# Altium schematic sheet （6）Memory.Sch
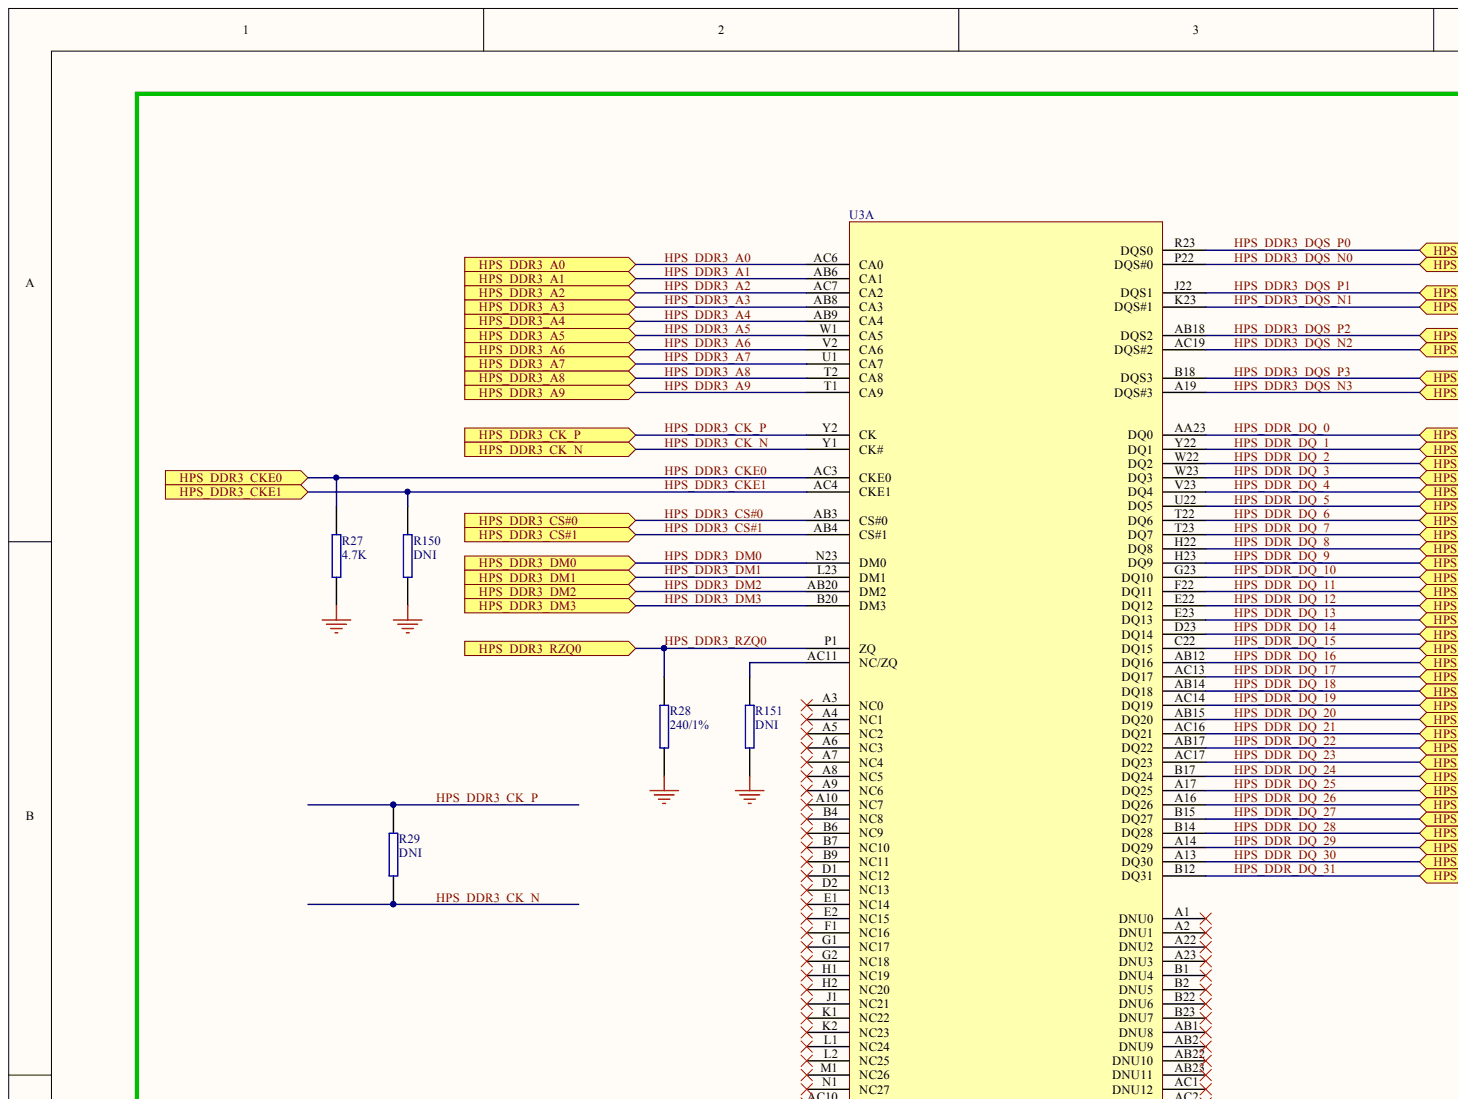
[diagram p0: （6）Memory.Sch, top left]
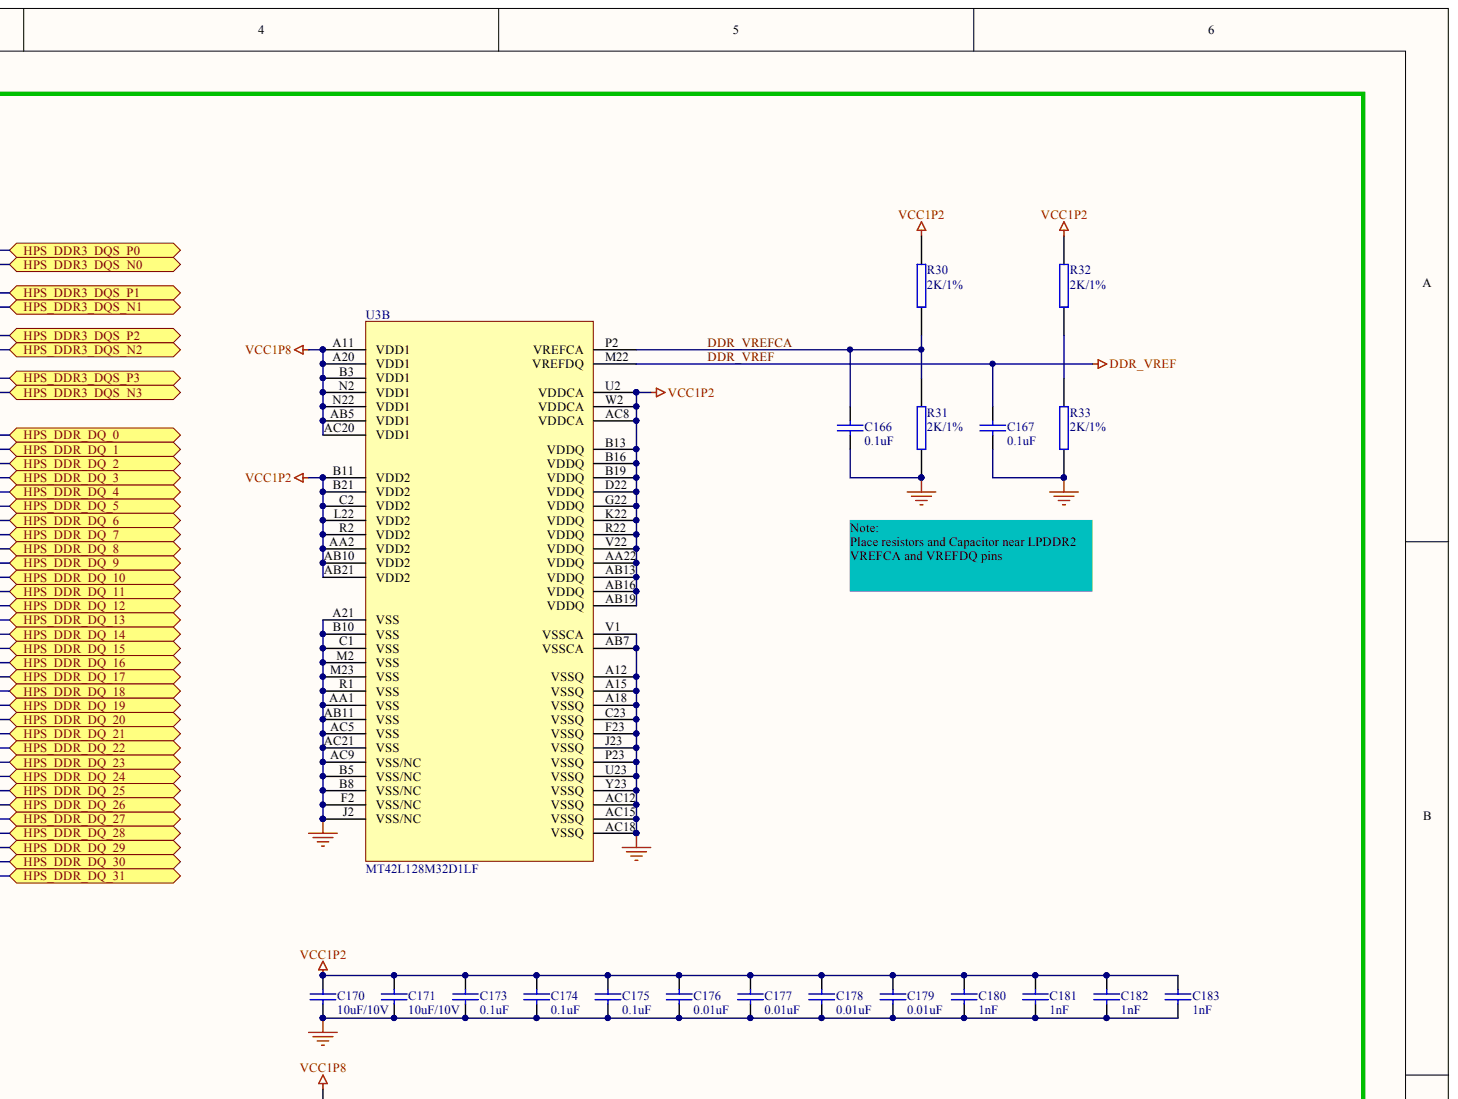
[diagram p1: （6）Memory.Sch, top right]
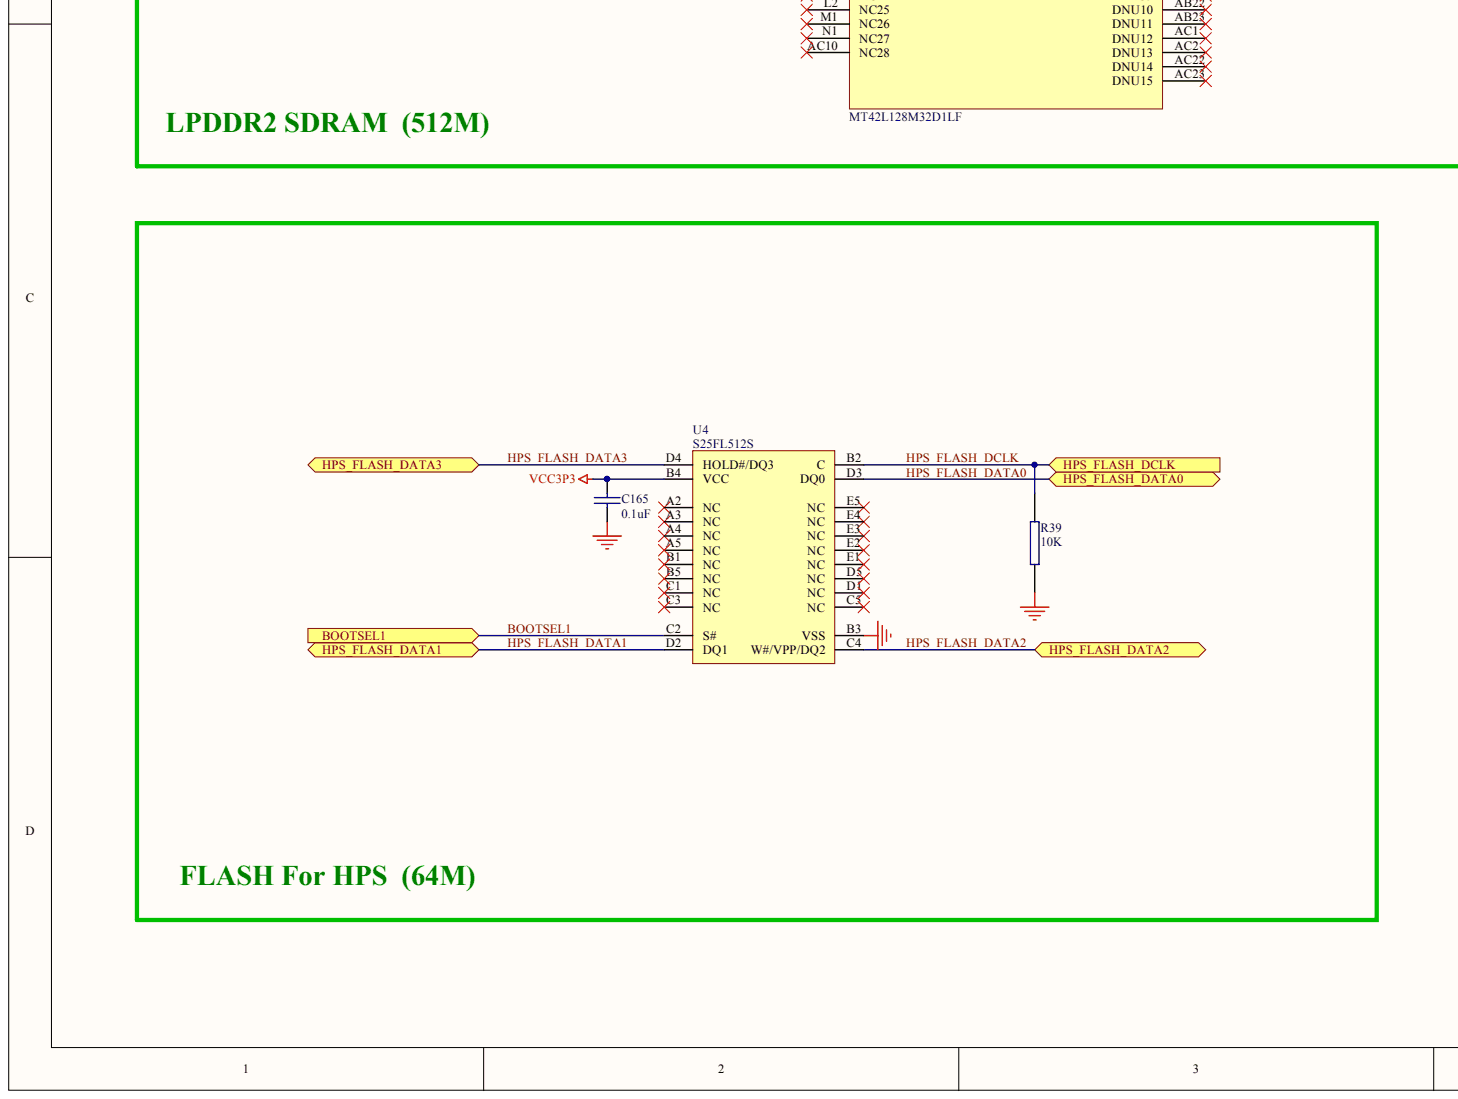
[diagram p2: （6）Memory.Sch, bottom left]
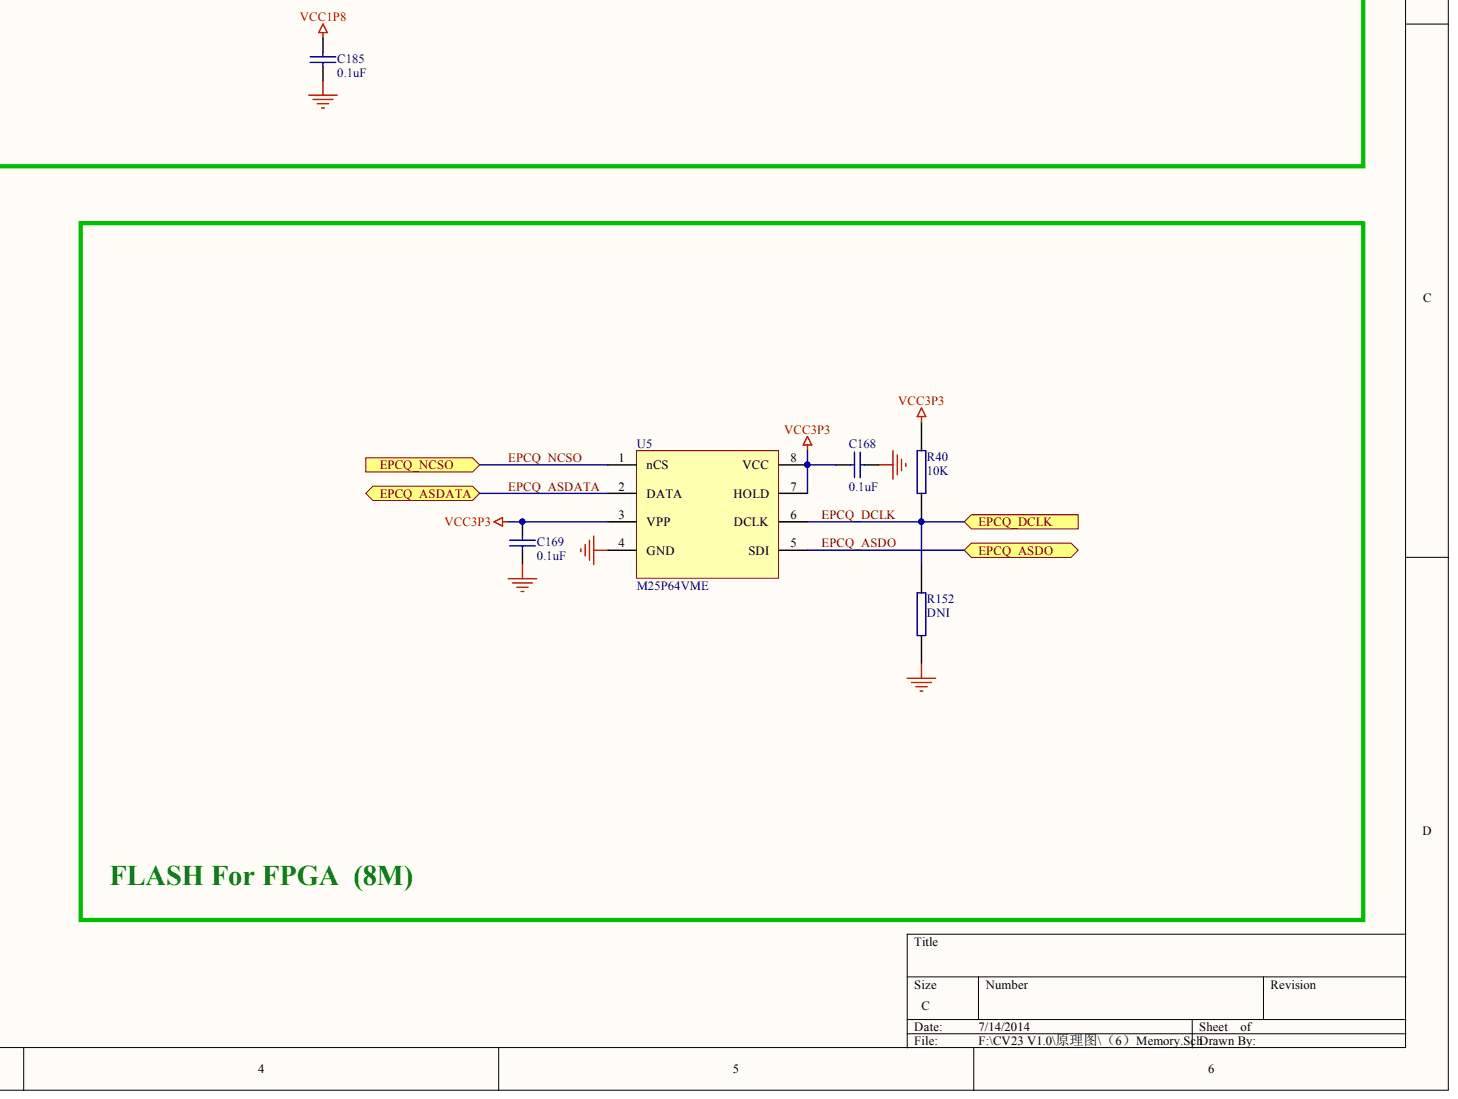
[diagram p3: （6）Memory.Sch, bottom right]

## Parts
C165  0.1uF
C166  0.1uF
C167  0.1uF
C168  0.1uF
C169  0.1uF
C170  10uF/10V
C171  10uF/10V
C173  0.1uF
C174  0.1uF
C175  0.1uF
C176  0.01uF
C177  0.01uF
C178  0.01uF
C179  0.01uF
C180  1nF
C181  1nF
C182  1nF
C183  1nF
C185  0.1uF
R27  4.7K
R28  240/1%
R29  DNI
R30  2K/1%
R31  2K/1%
R32  2K/1%
R33  2K/1%
R39  10K
R40  10K
R150  DNI
R151  DNI
R152  DNI
U3  MT42L128M32D1LF  (parts A,B)
U4  S25FL512S
U5  M25P64VME

## Ports
BOOTSEL1, EPCQ_ASDATA, EPCQ_ASDO, EPCQ_DCLK, EPCQ_NCSO, HPS_DDR3_A0, HPS_DDR3_A1, HPS_DDR3_A2, HPS_DDR3_A3, HPS_DDR3_A4, HPS_DDR3_A5, HPS_DDR3_A6, HPS_DDR3_A7, HPS_DDR3_A8, HPS_DDR3_A9, HPS_DDR3_CK_N, HPS_DDR3_CK_P, HPS_DDR3_CKE0, HPS_DDR3_CKE1, HPS_DDR3_CS#0, HPS_DDR3_CS#1, HPS_DDR3_DM0, HPS_DDR3_DM1, HPS_DDR3_DM2, HPS_DDR3_DM3, HPS_DDR3_DQS_N0, HPS_DDR3_DQS_N1, HPS_DDR3_DQS_N2, HPS_DDR3_DQS_N3, HPS_DDR3_DQS_P0, HPS_DDR3_DQS_P1, HPS_DDR3_DQS_P2, HPS_DDR3_DQS_P3, HPS_DDR3_RZQ0, HPS_DDR_DQ_0, HPS_DDR_DQ_1, HPS_DDR_DQ_2, HPS_DDR_DQ_3, HPS_DDR_DQ_4, HPS_DDR_DQ_5, HPS_DDR_DQ_6, HPS_DDR_DQ_7, HPS_DDR_DQ_8, HPS_DDR_DQ_9, HPS_DDR_DQ_10, HPS_DDR_DQ_11, HPS_DDR_DQ_12, HPS_DDR_DQ_13, HPS_DDR_DQ_14, HPS_DDR_DQ_15, HPS_DDR_DQ_16, HPS_DDR_DQ_17, HPS_DDR_DQ_18, HPS_DDR_DQ_19, HPS_DDR_DQ_20, HPS_DDR_DQ_21, HPS_DDR_DQ_22, HPS_DDR_DQ_23, HPS_DDR_DQ_24, HPS_DDR_DQ_25, HPS_DDR_DQ_26, HPS_DDR_DQ_27, HPS_DDR_DQ_28, HPS_DDR_DQ_29, HPS_DDR_DQ_30, HPS_DDR_DQ_31, HPS_FLASH_DATA0, HPS_FLASH_DATA1, HPS_FLASH_DATA2, HPS_FLASH_DATA3, HPS_FLASH_DCLK

## Nets
GND: C165.2 C166.2 C167.2 C168.2 C169.2 C170.2 C171.2 C173.2 C174.2 C175.2 C176.2 C177.2 C178.2 C179.2 C180.2 C181.2 C182.2 C183.2 C185.2 R27.1 R28.1 R31.1 R33.1 R39.2 R150.1 R151.1 R152.1 U3.A12(VSSQ) U3.A15(VSSQ) U3.A18(VSSQ) U3.A21(VSS) U3.AA1(VSS) U3.AB7(VSSCA) U3.AB11(VSS) U3.AC5(VSS) U3.AC9(VSS/NC) U3.AC12(VSSQ) U3.AC15(VSSQ) U3.AC18(VSSQ) U3.AC21(VSS) U3.B5(VSS/NC) U3.B8(VSS/NC) U3.B10(VSS) U3.C1(VSS) U3.C23(VSSQ) U3.F2(VSS/NC) U3.F23(VSSQ) U3.J2(VSS/NC) U3.J23(VSSQ) U3.M2(VSS) U3.M23(VSS) U3.P23(VSSQ) U3.R1(VSS) U3.U23(VSSQ) U3.V1(VSSCA) U3.Y23(VSSQ) U4.B3(VSS) U5.4(GND)
VCC1P2: C170.1 C171.1 C173.1 C174.1 C175.1 C176.1 C177.1 C178.1 C179.1 C180.1 C181.1 C182.1 C183.1 R30.2 R32.2 U3.AA2(VDD2) U3.AA22(VDDQ) U3.AB10(VDD2) U3.AB13(VDDQ) U3.AB16(VDDQ) U3.AB19(VDDQ) U3.AB21(VDD2) U3.AC8(VDDCA) U3.B11(VDD2) U3.B13(VDDQ) U3.B16(VDDQ) U3.B19(VDDQ) U3.B21(VDD2) U3.C2(VDD2) U3.D22(VDDQ) U3.G22(VDDQ) U3.K22(VDDQ) U3.L22(VDD2) U3.R2(VDD2) U3.R22(VDDQ) U3.U2(VDDCA) U3.V22(VDDQ) U3.W2(VDDCA)
VCC1P8: C185.1 U3.A11(VDD1) U3.A20(VDD1) U3.AB5(VDD1) U3.AC20(VDD1) U3.B3(VDD1) U3.N2(VDD1) U3.N22(VDD1)
VCC3P3: C165.1 C168.1 C169.1 R40.2 U4.B4(VCC) U5.3(VPP) U5.7(HOLD) U5.8(VCC)
BOOTSEL1: U4.C2(S#) ; port BOOTSEL1
DDR_VREF: C167.1 U3.M22(VREFDQ)
DDR_VREFCA: C166.1 R30.1 R31.2 U3.P2(VREFCA)
EPCQ_ASDATA: U5.2(DATA) ; port EPCQ_ASDATA
EPCQ_ASDO: U5.5(SDI) ; port EPCQ_ASDO
EPCQ_DCLK: R40.1 R152.2 U5.6(DCLK) ; port EPCQ_DCLK
EPCQ_NCSO: U5.1(nCS) ; port EPCQ_NCSO
HPS_DDR3_A0: U3.AC6(CA0) ; port HPS_DDR3_A0
HPS_DDR3_A1: U3.AB6(CA1) ; port HPS_DDR3_A1
HPS_DDR3_A2: U3.AC7(CA2) ; port HPS_DDR3_A2
HPS_DDR3_A3: U3.AB8(CA3) ; port HPS_DDR3_A3
HPS_DDR3_A4: U3.AB9(CA4) ; port HPS_DDR3_A4
HPS_DDR3_A5: U3.W1(CA5) ; port HPS_DDR3_A5
HPS_DDR3_A6: U3.V2(CA6) ; port HPS_DDR3_A6
HPS_DDR3_A7: U3.U1(CA7) ; port HPS_DDR3_A7
HPS_DDR3_A8: U3.T2(CA8) ; port HPS_DDR3_A8
HPS_DDR3_A9: U3.T1(CA9) ; port HPS_DDR3_A9
HPS_DDR3_CK_N: R29.1 U3.Y1(CK#) ; port HPS_DDR3_CK_N
HPS_DDR3_CK_P: R29.2 U3.Y2(CK) ; port HPS_DDR3_CK_P
HPS_DDR3_CKE0: R27.2 U3.AC3(CKE0) ; port HPS_DDR3_CKE0
HPS_DDR3_CKE1: R150.2 U3.AC4(CKE1) ; port HPS_DDR3_CKE1
HPS_DDR3_CS#0: U3.AB3(CS#0) ; port HPS_DDR3_CS#0
HPS_DDR3_CS#1: U3.AB4(CS#1) ; port HPS_DDR3_CS#1
HPS_DDR3_DM0: U3.N23(DM0) ; port HPS_DDR3_DM0
HPS_DDR3_DM1: U3.L23(DM1) ; port HPS_DDR3_DM1
HPS_DDR3_DM2: U3.AB20(DM2) ; port HPS_DDR3_DM2
HPS_DDR3_DM3: U3.B20(DM3) ; port HPS_DDR3_DM3
HPS_DDR3_DQS_N0: U3.P22(DQS#0) ; port HPS_DDR3_DQS_N0
HPS_DDR3_DQS_N1: U3.K23(DQS#1) ; port HPS_DDR3_DQS_N1
HPS_DDR3_DQS_N2: U3.AC19(DQS#2) ; port HPS_DDR3_DQS_N2
HPS_DDR3_DQS_N3: U3.A19(DQS#3) ; port HPS_DDR3_DQS_N3
HPS_DDR3_DQS_P0: U3.R23(DQS0) ; port HPS_DDR3_DQS_P0
HPS_DDR3_DQS_P1: U3.J22(DQS1) ; port HPS_DDR3_DQS_P1
HPS_DDR3_DQS_P2: U3.AB18(DQS2) ; port HPS_DDR3_DQS_P2
HPS_DDR3_DQS_P3: U3.B18(DQS3) ; port HPS_DDR3_DQS_P3
HPS_DDR3_RZQ0: R28.2 U3.P1(ZQ) ; port HPS_DDR3_RZQ0
HPS_DDR_DQ_0: U3.AA23(DQ0) ; port HPS_DDR_DQ_0
HPS_DDR_DQ_1: U3.Y22(DQ1) ; port HPS_DDR_DQ_1
HPS_DDR_DQ_2: U3.W22(DQ2) ; port HPS_DDR_DQ_2
HPS_DDR_DQ_3: U3.W23(DQ3) ; port HPS_DDR_DQ_3
HPS_DDR_DQ_4: U3.V23(DQ4) ; port HPS_DDR_DQ_4
HPS_DDR_DQ_5: U3.U22(DQ5) ; port HPS_DDR_DQ_5
HPS_DDR_DQ_6: U3.T22(DQ6) ; port HPS_DDR_DQ_6
HPS_DDR_DQ_7: U3.T23(DQ7) ; port HPS_DDR_DQ_7
HPS_DDR_DQ_8: U3.H22(DQ8) ; port HPS_DDR_DQ_8
HPS_DDR_DQ_9: U3.H23(DQ9) ; port HPS_DDR_DQ_9
HPS_DDR_DQ_10: U3.G23(DQ10) ; port HPS_DDR_DQ_10
HPS_DDR_DQ_11: U3.F22(DQ11) ; port HPS_DDR_DQ_11
HPS_DDR_DQ_12: U3.E22(DQ12) ; port HPS_DDR_DQ_12
HPS_DDR_DQ_13: U3.E23(DQ13) ; port HPS_DDR_DQ_13
HPS_DDR_DQ_14: U3.D23(DQ14) ; port HPS_DDR_DQ_14
HPS_DDR_DQ_15: U3.C22(DQ15) ; port HPS_DDR_DQ_15
HPS_DDR_DQ_16: U3.AB12(DQ16) ; port HPS_DDR_DQ_16
HPS_DDR_DQ_17: U3.AC13(DQ17) ; port HPS_DDR_DQ_17
HPS_DDR_DQ_18: U3.AB14(DQ18) ; port HPS_DDR_DQ_18
HPS_DDR_DQ_19: U3.AC14(DQ19) ; port HPS_DDR_DQ_19
HPS_DDR_DQ_20: U3.AB15(DQ20) ; port HPS_DDR_DQ_20
HPS_DDR_DQ_21: U3.AC16(DQ21) ; port HPS_DDR_DQ_21
HPS_DDR_DQ_22: U3.AB17(DQ22) ; port HPS_DDR_DQ_22
HPS_DDR_DQ_23: U3.AC17(DQ23) ; port HPS_DDR_DQ_23
HPS_DDR_DQ_24: U3.B17(DQ24) ; port HPS_DDR_DQ_24
HPS_DDR_DQ_25: U3.A17(DQ25) ; port HPS_DDR_DQ_25
HPS_DDR_DQ_26: U3.A16(DQ26) ; port HPS_DDR_DQ_26
HPS_DDR_DQ_27: U3.B15(DQ27) ; port HPS_DDR_DQ_27
HPS_DDR_DQ_28: U3.B14(DQ28) ; port HPS_DDR_DQ_28
HPS_DDR_DQ_29: U3.A14(DQ29) ; port HPS_DDR_DQ_29
HPS_DDR_DQ_30: U3.A13(DQ30) ; port HPS_DDR_DQ_30
HPS_DDR_DQ_31: U3.B12(DQ31) ; port HPS_DDR_DQ_31
HPS_FLASH_DATA0: U4.D3(DQ0) ; port HPS_FLASH_DATA0
HPS_FLASH_DATA1: U4.D2(DQ1) ; port HPS_FLASH_DATA1
HPS_FLASH_DATA2: U4.C4(W#/VPP/DQ2) ; port HPS_FLASH_DATA2
HPS_FLASH_DATA3: U4.D4(HOLD#/DQ3) ; port HPS_FLASH_DATA3
HPS_FLASH_DCLK: R39.1 U4.B2(C) ; port HPS_FLASH_DCLK
NetR32_1: R32.1 R33.2
NetR151_2: R151.2 U3.AC11(NC/ZQ)

Not connected: U3 A1(DNU0) A2(DNU1) A3(NC0) A4(NC1) A5(NC2) A6(NC3) A7(NC4) A8(NC5) A9(NC6) A10(NC7) A22(DNU2) A23(DNU3) AB1(DNU8) AB2(DNU9) AB22(DNU10) AB23(DNU11) AC1(DNU12) AC2(DNU13) AC10(NC28) AC22(DNU14) AC23(DNU15) B1(DNU4) B2(DNU5) B4(NC8) B6(NC9) B7(NC10) B9(NC11) B22(DNU6) B23(DNU7) D1(NC12) D2(NC13) E1(NC14) E2(NC15) F1(NC16) G1(NC17) G2(NC18) H1(NC19) H2(NC20) J1(NC21) K1(NC22) K2(NC23) L1(NC24) L2(NC25) M1(NC26) N1(NC27); U4 A2(NC) A3(NC) A4(NC) A5(NC) B1(NC) B5(NC) C1(NC) C3(NC) C5(NC) D1(NC) D5(NC) E1(NC) E2(NC) E3(NC) E4(NC) E5(NC)
